# Altium schematic sheet schematic_A.SchDoc(schematic_A)
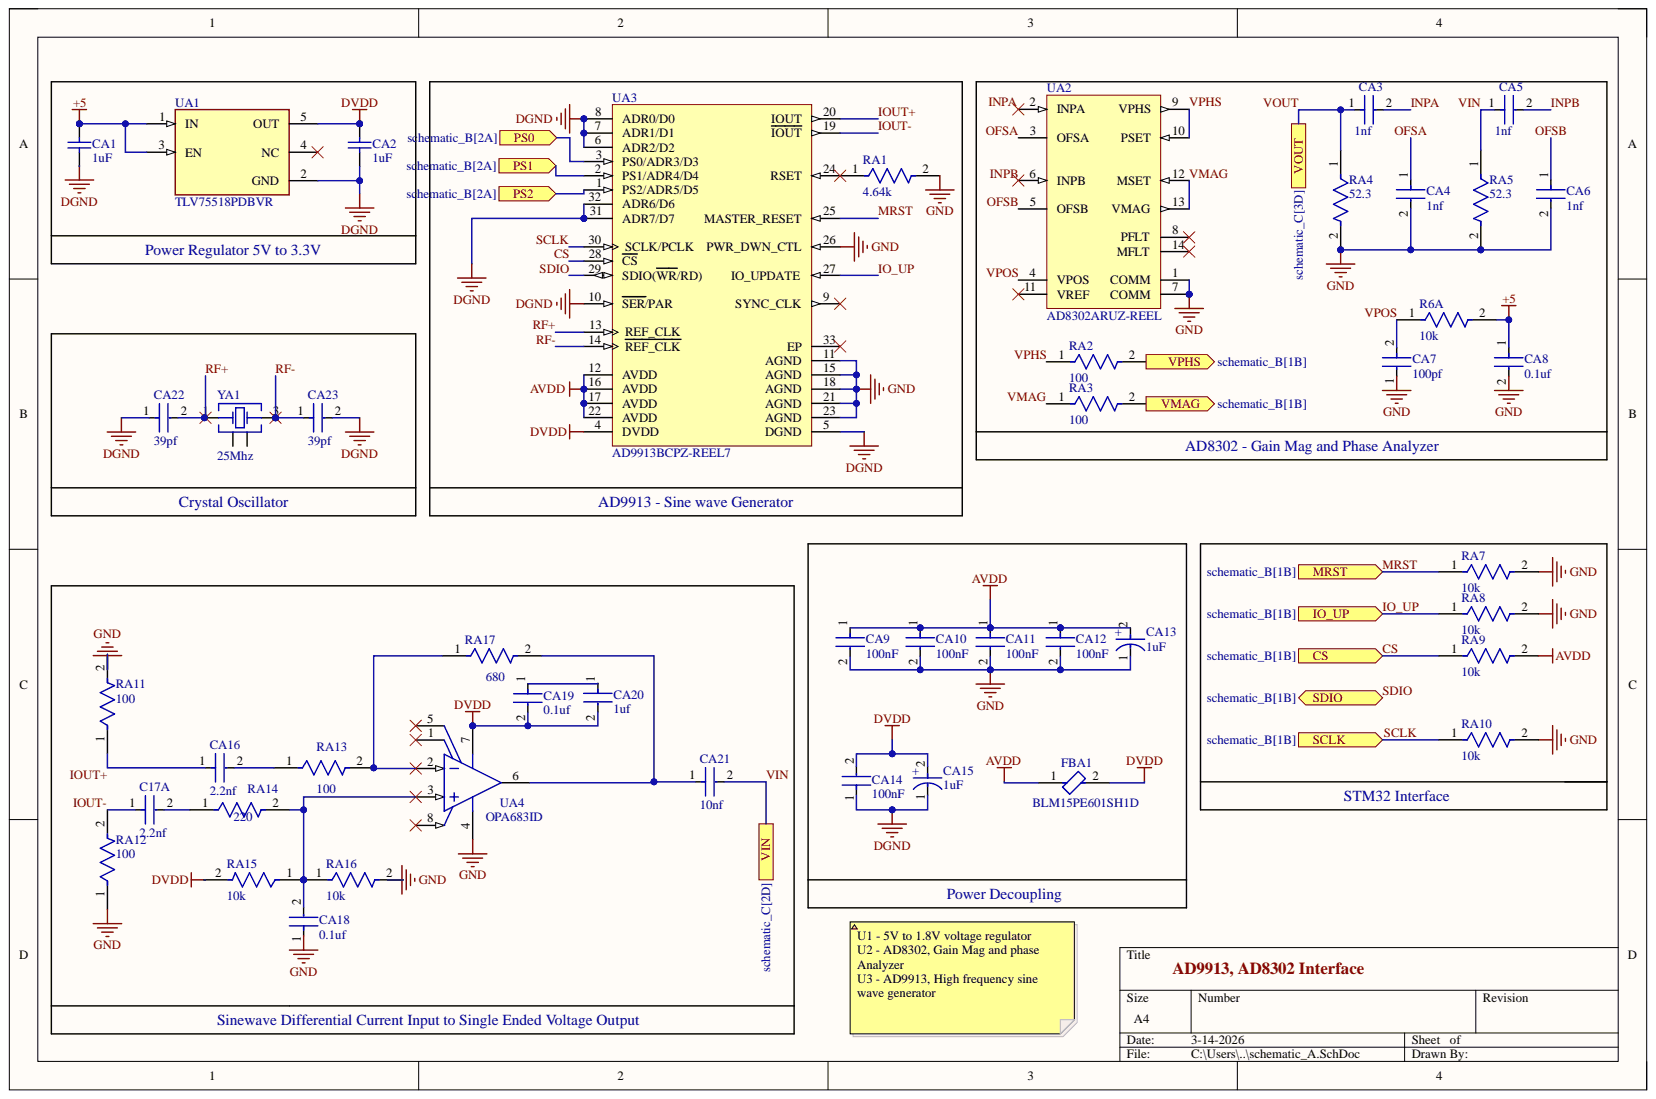
[diagram p0: schematic_A.SchDoc]

## Parts
C17A  2.2nf  [FP-GCM188-0_1-MFG]
CA1  1uF  [CAPC0603X33X15NL03T05]
CA2  1uF  [CAPC0603X33X15NL03T05]
CA3  1nf  [FP-GCM21B-0_2-e0_3_0_75-MFG]
CA4  1nf  [FP-GCM21B-0_2-e0_3_0_75-MFG]
CA5  1nf  [FP-GCM21B-0_2-e0_3_0_75-MFG]
CA6  1nf  [FP-GCM21B-0_2-e0_3_0_75-MFG]
CA7  100pf  [FP-GRT033-0_03-IPC_B]
CA8  0.1uf  [FP-GRM188-0_1-e0_2_0_5-MFG]
CA9  100nF  [FP-0402-L_1_0_0_05-W_0_5-MFG]
CA10  100nF  [FP-0402-L_1_0_0_05-W_0_5-MFG]
CA11  100nF  [FP-0402-L_1_0_0_05-W_0_5-MFG]
CA12  100nF  [FP-0402-L_1_0_0_05-W_0_5-MFG]
CA13  1uF  [FP-293DA-MFG]
CA14  100nF  [FP-0402-L_1_0_0_05-W_0_5-MFG]
CA15  1uF  [FP-293DA-MFG]
CA16  2.2nf  [FP-GCM188-0_1-MFG]
CA18  0.1uf  [FP-GRM188-0_1-e0_2_0_5-MFG]
CA19  0.1uf  [FP-GRM188-0_1-e0_2_0_5-MFG]
CA20  1uf  [FP-CGA3E-0_3-0_1-IPC_B]
CA21  10nf  [FP-GRM022-0_02-IPC_B]
CA22  39pf  [FP-GRM033-0_03-IPC_A]
CA23  39pf  [FP-GRM033-0_03-IPC_A]
FBA1  BLM15PE601SH1D  [FP-BLM15-0_05-MFG]
R6A  10k  [FP-AT0805-MFG]
RA1  4.64k  [FP-RN731J-MFG]
RA2  100  [FP-RNCP0603-MFG]
RA3  100  [FP-RNCP0603-MFG]
RA4  52.3  [FP-RN731E-MFG]
RA5  52.3  [FP-RN731E-MFG]
RA7  10k  [FP-CRGCQ0402-IPC_C]
RA8  10k  [FP-CRGCQ0402-IPC_C]
RA9  10k  [FP-CRGCQ0402-IPC_C]
RA10  10k  [FP-CRGCQ0402-IPC_C]
RA11  100  [FP-RNCP0603-MFG]
RA12  100  [FP-RNCP0603-MFG]
RA13  100  [FP-RNCP0603-MFG]
RA14  220  [FP-MCT0603-IPC_A]
RA15  10k  [FP-AT0805-MFG]
RA16  10k  [FP-AT0805-MFG]
RA17  680  [FP-RT0402-MFG]
UA1  TLV75518PDBVR  [FP-DBV0005A-IPC_A]
UA2  AD8302ARUZ-REEL  [ADI-RU-14_M]
UA3  AD9913BCPZ-REEL7  [ADI-CP-32-2_L]
UA4  OPA683ID  [D0008A_L]
YA1  25Mhz  [WE-XTAL_CFPX-180]

## Ports
CS, IO_UP, MRST, PS0, PS1, PS2, SCLK, SDIO, VIN, VMAG, VOUT, VPHS

## Nets
+5: CA1.1 CA8.1 R6A.2 UA1.1(IN) UA1.3(EN)
AVDD: CA9.1 CA10.1 CA11.1 CA12.1 CA13.2 FBA1.1 RA9.2 UA3.12(AVDD) UA3.16(AVDD) UA3.17(AVDD) UA3.22(AVDD)
DGND: CA1.2 CA2.2 CA14.1 CA15.1 CA22.1 CA23.2 UA1.2(GND) UA3.5(DGND) UA3.6(ADR2/D2) UA3.7(ADR1/D1) UA3.8(ADR0/D0) UA3.10(SER/PAR) UA3.31(ADR7/D7) UA3.32(ADR6/D6)
DVDD: CA2.1 CA14.2 CA15.2 CA19.2 CA20.2 FBA1.2 RA15.2 UA1.5(OUT) UA3.4(DVDD) UA4.7
GND: CA4.2 CA6.2 CA7.1 CA8.2 CA9.2 CA10.2 CA11.2 CA12.2 CA13.1 CA18.1 RA1.2 RA4.2 RA5.2 RA7.2 RA8.2 RA10.2 RA11.2 RA12.1 RA16.2 UA2.1(COMM) UA2.7(COMM) UA3.11(AGND) UA3.15(AGND) UA3.18(AGND) UA3.21(AGND) UA3.23(AGND) UA3.26(PWR_DWN_CTL) UA4.4
CS: RA9.1 UA3.28(CS) ; port CS
INPA: CA3.2 UA2.2(INPA)
INPB: CA5.2 UA2.6(INPB)
IO_UP: RA8.1 UA3.27(IO_UPDATE) ; port IO_UP
IOUT+: CA16.1 RA11.1 UA3.20(IOUT)
IOUT-: C17A.1 RA12.2 UA3.19(IOUT)
MRST: RA7.1 UA3.25(MASTER_RESET) ; port MRST
OFSA: CA4.1 UA2.3(OFSA)
OFSB: CA6.1 UA2.5(OFSB)
PS0: UA3.3(PS0/ADR3/D3) ; port PS0
PS1: UA3.2(PS1/ADR4/D4) ; port PS1
PS2: UA3.1(PS2/ADR5/D5) ; port PS2
RF+: CA22.2 UA3.13(REF_CLK) YA1.1
RF-: CA23.1 UA3.14(REF_CLK) YA1.3
SCLK: RA10.1 UA3.30(SCLK/PCLK) ; port SCLK
SDIO: UA3.29(SDIO(WR/RD)) ; port SDIO
VIN: CA5.1 CA21.2 RA5.1 ; port VIN
VMAG: RA3.1 UA2.12(MSET) UA2.13(VMAG)
VOUT: CA3.1 RA4.1 ; port VOUT
VPHS: RA2.1 UA2.9(VPHS) UA2.10(PSET)
VPOS: CA7.2 R6A.1 UA2.4(VPOS)
NetC17A_2: C17A.2 RA14.1
NetCA16_2: CA16.2 RA13.1
NetCA18_2: CA18.2 RA14.2 RA15.1 RA16.1 UA4.3
NetCA19_1: CA19.1 CA20.1
NetCA21_1: CA21.1 RA17.2 UA4.6
NetRA1_1: RA1.1 UA3.24(RSET)
NetRA2_2: RA2.2 ; port VPHS
NetRA3_2: RA3.2 ; port VMAG
NetRA13_2: RA13.2 RA17.1 UA4.2

Not connected: UA1 4(NC); UA2 8(PFLT) 11(VREF) 14(MFLT); UA3 9(SYNC_CLK) 33(EP); UA4 1 5 8; YA1 2 4

## Library data of the parts
C17A CA16 = CMP-06035-034799-1: Ceramic Capacitor for Automotive 2.2nF  ±5% 63VDC C0G 0603 Paper T/R; Component Kind: Standard; Library Name: Altium Content Vault
CA1 CA2 = CMP-2010-00160-1: Manufacturer: AVX Interconnect / Elco; Part Number: 02016D105KAT2A; Component Kind: Standard; Library Name: Altium Content Vault
CA3-CA6 = CMP-06035-041052-1: Ceramic Capacitor for Automotive 1nF ±5% 630VDC C0G 0805 Embossed T/R; Component Kind: Standard; Library Name: Altium Content Vault
CA7 = CMP-06035-012756-1: Multi-Layer Ceramic Capacitor 100pF 25V C0G ±5% 0201 Paper T/R; Component Kind: Standard; Library Name: Altium Content Vault
CA8 CA18 CA19 = CMP-06035-009454-1: Chip Multilayer Ceramic Capacitors for General Purpose, 0603, 0.10uF, X7R, 15%, 20%, 100V; Capacitance: 100nF; Component Kind: Standard; Dielectric: X7R; Length: 1.6mm; Library Name: Altium Content Vault; Thickness: 800um; Tolerance: 20%; Voltage Rating (DC): 100V; Width: 800um
CA9-CA12 CA14 = CMP-14477-003271-3: Ceramic Capacitor General Use 0.1uF ±10% 25V X5R 0402; NexarPartId: 49252556; Capacitance: 100nF; Case/Package: 0402; Component Kind: Standard; Halogen Free: Halogen Free; Height: 550µm; Length: 990.6µm; Library Name: Altium Content Vault; Material: Ceramic; Max Length: 1.05mm; Max Operating Temperature: 85°C; Max Thickness: 550µm; Max Width: 550µm; Min Length: 950µm; Min Operating Temperature: -55°C; Min Thickness: 450µm; Min Width: 450µm; Mount: Surface Mount; Packaging: Tape & Reel (TR); RoHS: Compliant; Thickness: 558.8µm; Tolerance: 10%; Voltage Rating: 25V; Voltage Rating (DC): 25V; Width: 508µm
CA13 CA15 = CMP-00030-40018767-1: 293D105X9020A2TE3 Cap Tant Solid 1uF 20V A CASE 10% (3.2 X 1.6 X 1.6mm) SMD 3216-18 8.4 Ohm 125 C T/R; Capacitance: 1µF; Dielectric Material: Tantalum; Dissipation Factor: 4%; ESR (Equivalent Series Resistance): 8.4Ω; Leakage Current: 500nA; Polarity: Polar; Q Factor: 4; Ripple Current: 90mA; Tolerance: 20%; Case Code (Imperial): 1206; Case Code (Metric): 3216; Case/Package: 3216-18; Component Kind: Standard; Depth: 1.6mm; Features: General Purpose; Height: 1.6mm; Height - Seated (Max): 1.8034mm; Lead Free: Lead Free; Length: 3.2mm; Library Name: Altium Content Vault; Max Operating Temperature: 125°C; Min Operating Temperature: -55°C; Mount: PCB, Surface Mount; NexarPartId: 40018767; Number of Pins: 2; Packaging: Tape and Reel; Radiation Hardening: No; REACH SVHC: Unknown; RoHS: Compliant; Schedule B: 8532210050, 8532210050; Termination: SMD/SMT; Voltage: 20V; Voltage Rating: 20V; Voltage Rating (DC): 20V; Width: 1.6mm
CA20 = CMP-08246-001878-1: Cap Ceramic 1uF 25V X7R 20% Pad SMD 0603 +125°C Automotive T/R; Capacitance: 1uF; Case Code (Imperial): 0603; Case Code (Metric): 1608; Case/Package: 0603; Component Kind: Standard; Dielectric: X7R; Height: 0.8mm; Length: 0.063inch; Library Name: Altium Content Vault; Max Operating Temperature: 125°C; Min Operating Temperature: -55°C; Mount: Surface Mount; Package Quantity: 4000; Packaging: Tape and Reel; Ratings: AEC-Q200; Thickness: 0.031inch; Tolerance: 20%; Voltage Rating: 25V; Voltage Rating (DC): 25V; Weight: 7.1E-05oz; Width: 0.031inch
CA21 = CMP-06035-063197-1: Chip Multilayer Ceramic Capacitors for General Purpose, 10000pF ±20%, X6S(EIA), ±22.0%, 4Vdc, 01005 (0402M); Component Kind: Standard; Library Name: Altium Content Vault
CA22 CA23 = CMP-2010-01365-2: Chip Multilayer Ceramic Capacitors for General Purpose, 0201, 39pF, C0G, 30ppm/°C, 5%, 25V; Capacitance: 39pF; Case Code (Imperial): 0201; Case Code (Metric): 0603; Case/Package: 0201; Component Kind: Standard; Depth: 0.3mm; Dielectric: C0G; Height: 0.3mm; Lead Free: Lead Free; Length: 0.6mm; Library Name: Altium Content Vault; Max Operating Temperature: 125°C; Min Operating Temperature: -55°C; Mount: Surface Mount; Package Quantity: 15000; Packaging: Tape and Reel; Termination: SMD/SMT; Thickness: 0.013inch; Tolerance: 5%; Voltage Rating: 25V; Voltage Rating (DC): 25V; Width: 0.012inch
FBA1 = CMP-00260-117051428-1: BLM15PE601SH1D Ferrite Bead, 600 Ohm, 25%, 0402 Rohs Compliant: Yes; Resistance: 400mΩ; RMS Current (Irms): 700mA; Test Frequency: 100MHz; Case/Package: 0402; Component Kind: Standard; Core Material: Ferrite; Height: 550µm; Library Name: Altium Content Vault; Lifecycle Status: Production; Max Operating Temperature: 125°C; Min Operating Temperature: -55°C; NexarPartId: 117051428; Series Resistance: 400mΩ; Tolerance: 25%
R6A RA15 RA16 = CMP-03412-049031-1: Thin Film Chip Resistors Automotive Grade 10kΩ 0.5% 50ppm/°C 0805; Max Power Dissipation: 125mW; Resistance: 10kR; Case/Package: 0805; Component Kind: Standard; Features: Moisture Resistant; Height: 0.024inch; Length: 0.079inch; Library Name: Altium Content Vault; Max Operating Temperature: 155°C; Min Operating Temperature: -55°C; Number of Terminations: 2; Packaging: Tape and Reel; Ratings: AEC-Q200; Resistor Type: Thin Film; RoHS Compliant: Yes; Series: AT; Temperature Coefficient: 50ppm/°C; Tolerance: 0.5%; Width: 0.049inch
RA1 = CMP-03211-005291-1: Thin Film Resistors RN 0603 4.64KΩ 0.1% 10ppm/°C; Manufacturer: TE Connectivity; Manufacturer Part Number: RN73C1J4K64BTG; Power Rating: 0.063W; Voltage Rating: 50V; Automotive: No; Category: Res; Component Kind: Standard; ComponentLink2Description: Datasheet; ComponentLink2URL: https://www.te.com/commerce/DocumentDelivery/DDEController?Action=showdoc&DocId=Data+Sheet%7F1773270%7FP%7Fpdf%7FEnglish%7FENG_DS_1773270_P.pdf%7F9-1614352-9; Composition: Thin Film; Device Class L1: Passive Components; Device Class L2: Resistors; Device Class L3: Chip SMD Resistors; Height: 0.55mm; Ipc Land Pattern Name: RESC15580X45; Lead Free: Yes; Library Name: Altium Content Vault; Octopart: https://octopart.com/rn73c1j4k64btg-te+connectivity-44606189; octopart_url: https://octopart.com/rn73c1j4k64btg-te+connectivity-44606189; Package: RN731J; packaging: 250 cut tape length; Resistance: 4.64KΩ; RoHS: TRUE; Series: RN73; Temperature: -55°C to +155°C; Temperature Coefficient: 10ppm/°C; Temperature Range High: +155°C; Temperature Range Low: -55°C; Tolerance: 0.1%; Value: 4.64KΩ
RA2 RA3 RA11-RA13 = CMP-26527-000148-1: 100Ω ±1% 0.125W 0603 High Power Anti-Sulfur Thin Film Chip Resistor; Max Power Dissipation: 125mW; Resistance: 100R; Temperature Coefficient: 100ppm/°C; Case Code (Imperial): 0603; Case Code (Metric): 1608; Case/Package: 0603; Component Kind: Standard; Contact Plating: Tin; Features: Moisture Resistant; Height: 0.02inch; Lead Free: Lead Free; Length: 0.059inch; Library Name: Altium Content Vault; Max Operating Temperature: 155°C; Min Operating Temperature: -55°C; Mount: Surface Mount; Number of Terminations: 2; Packaging: Tape and Reel; Radiation Hardening: No; Resistor Type: Thin Film; RoHS Compliant: Yes; Series: RNCP; Tolerance: 1%; Width: 0.031inch
RA4 RA5 = CMP-03211-009005-1: Res Thin Film 0402 52.3Ω 0.1% 1/16W 10ppm/°C Molded SMD; Manufacturer: TE Connectivity; Manufacturer Part Number: RN73C1E52R3BTDF; Power Rating: 0.063W; Voltage Rating: 25V; Automotive: No; Category: Res; Component Kind: Standard; ComponentLink2Description: Datasheet; ComponentLink2URL: https://www.te.com/commerce/DocumentDelivery/DDEController?Action=showdoc&DocId=Data+Sheet%7F1773270%7FP%7Fpdf%7FEnglish%7FENG_DS_1773270_P.pdf%7F1-1879132-6; Composition: Thin Film; Device Class L1: Passive Components; Device Class L2: Resistors; Device Class L3: Chip SMD Resistors; Height: 0.35mm; Ipc Land Pattern Name: RESC10050X30; Lead Free: Yes; Library Name: Altium Content Vault; Octopart: https://octopart.com/rn73c1e52r3btdf-te+connectivity-22737981; octopart_url: https://octopart.com/rn73c1e52r3btdf-te+connectivity-22737981; Package: RN731E; packaging: 1000 Reel; Resistance: 52.3Ω; RoHS: TRUE; Series: RN73; Temperature: -55°C to +155°C; Temperature Coefficient: 10ppm/°C; Temperature Range High: +155°C; Temperature Range Low: -55°C; Tolerance: 0.1%; Value: 52.3Ω
RA7-RA10 = CMP-03211-008081-1: CRGCQ 0402 10K 5%; Manufacturer: TE Connectivity; Manufacturer Part Number: CRGCQ0402J10K; Power Rating: 0.0625W; Resistance: 10KΩ; Temperature Coefficient: ±100ppm/°C; Tolerance: 0.05; Value: 10KΩ; Voltage Rating: 50V; Ambient Temperature Range High: +70°C; Automotive: Yes; Category: Res; Component Kind: Standard; ComponentLink2Description: Datasheet; ComponentLink2URL: https://www.te.com/commerce/DocumentDelivery/DDEController?Action=showdoc&DocId=Data+Sheet%7F1773204-3%7F1%7Fpdf%7FEnglish%7FENG_DS_1773204-3_1.pdf%7F4-2176347-923; Composition: Thick Film; Device Class L1: Passive Components; Device Class L2: Resistors; Device Class L3: Chip SMD Resistors; Digikey Description: CRGCQ 0402 10K 5%; Height: 0.4mm; Ipc Land Pattern Name: RESC10050X35; Lead Free: Yes; Library Name: Altium Content Vault; Mouser Description: Res Thick Film 0402 10K Ohm 5% 0.063W(1/16W) ±100ppm/C Pad SMD Automotive T/R; Octopart: https://octopart.com/crgcq0402j10k-te+connectivity-91017993; Package: CRGCQ0402; RoHS: TRUE; Series: CRGCQ; Temperature: -55°C to +155°C; Temperature Range High: +155°C; Temperature Range Low: -55°C
RA14 = CMP-02407-001561-1: RES SMD 100 OHM 1% 1/8W 0603; Resistance: 220R; Tolerance: 0.5%; Case/Package: 0603; Component Kind: Standard; Composition: Thin Film; Depth: 0.85mm; Lead Free: Lead Free; Length: 1.55mm; Library Name: Altium Content Vault; Max Operating Temperature: 125°C; Military Standard: Not; Min Operating Temperature: -55°C; Packaging: Tape and Reel
RA17 = CMP-2002-02566-2: RES SMD 680 OHM 1% 1/16W 0402; Manufacturer: Yageo; Manufacturer Part Number: RT0402FRE07680RL; Power Rating: 0.063W; Resistance: 680Ω; Temperature Coefficient: 50 ppm/°C; Tolerance: 0.01; Value: 680Ω; Voltage Rating: 50V; Automotive: No; Category: Res; Component Kind: Standard; ComponentLink2Description: Datasheet; ComponentLink2URL: http://www.yageo.com/documents/recent/PYu-RT_1-to-0.01_RoHS_L_9.pdf; Composition: Thin Film; Device Class L1: Passive Components; Device Class L2: Resistors; Device Class L3: Chip SMD Resistors; Digikey Description: RES SMD 680 OHM 1% 1/16W 0402; Footprint Url: http://www.yageo.com/exep/pages/download/literatures/PYu-R_Mount_10.pdf; Height: 0.35mm; Ipc Land Pattern Name: RESC10050X30; Lead Free: Yes; Library Name: Altium Content Vault; Mouser Description: Res Thin Film 0402 680 Ohm 1% 1/16W ±50ppm/°C Molded SMD SMD Paper T/R; Octopart: https://octopart.com/rt0402fre07680rl-yageo-1234572; Package: RT0402; RoHS: TRUE; Series: RT; Temperature: -55°C to +155°C; Temperature Range High: +155°C; Temperature Range Low: -55°C
UA1 = CMP-04917-000157-1: IC REG LINEAR 1.8V 500MA SOT23-5; Dropout Voltage: 400mV; Max Input Voltage: 5.5V; Max Output Voltage: 3.3V; Number of Regulators: 1; Output Configuration: Positive; Output Type: Fixed; Power Supply Rejection Ratio (PSRR): 52dB; Quiescent Current: 31uA; Case/Package: SOT-23-5; Component Kind: Standard; Library Name: Altium Content Vault; Max Operating Temperature: 125°C; Min Operating Temperature: -40°C; Min Output Voltage: 1.8V; Mount: Surface Mount; Output Current: 500mA; Packaging: Cut Tape
UA2 = CMP-0284-00077-1: LF-2.7 GHz RF/IF Gain and Phase Detector, 60ns Response Time, RU-14, Pb-Free, 2500 Reel; Dynamic Range (dB): 60dB; Product Description: Dual-Channel Gain and Phase Outputs; RF Frequency (MHz): 2700; Supply Current: 19mA; Temp Stability (dB): 1dB; Voltage Supply (V): 2.7 to 5.5; Code_JEDEC: MO-153AB-1; Component Kind: Standard; ComponentLink1Description: Manufacturer URL; ComponentLink1URL: www.analog.com; ComponentLink2Description: Datasheet; ComponentLink2URL: http://www.analog.com/static/imported-files/data_sheets/AD8302.pdf; ComponentLink3Description: Package Specification; ComponentLink3URL: http://www.analog.com/static/imported-files/packages/36057385283879153717235186773RU14.pdf; DatasheetVersion: Rev. A; Library Name: Altium Content Vault; PackageDescription: 14-Lead Thin Shrink Small Outline Package [TSSOP]; PackageReference: RU-14; PackageVersion: Aug-2002; Response Time (ns typ): 60ns
UA3 = CMP-0293-00008-1: Low Power 250 MSPS 10-Bit DAC 1.8 V CMOS Direct Digital Synthesizer, Parallel, Serial, CP-32-2, 1500 Reel, Pb-Free; Compliance Range (V): 800mV; FS Iout (mA nom): 4.6mA; I Supply total (max): 63.5mA; Master fclk: 250MHz; REFCLK Multiplier: Yes; Resolution (Bits): 10bit; Tuning Word Width (bits): 32bit; Code_JEDEC: MO-220-VHHD-2; Component Kind: Standard; ComponentLink1Description: Manufacturer URL; ComponentLink1URL: www.analog.com; ComponentLink2Description: Datasheet; ComponentLink2URL: http://www.analog.com/static/imported-files/data_sheets/AD9913.pdf; ComponentLink3Description: Package Specification; ComponentLink3URL: http://www.analog.com/static/imported-files/packages/414143737956480539664569CP_32_2.pdf; DatasheetVersion: Rev. A; I/O Interface: Parallel, Serial; Library Name: Altium Content Vault; PackageDescription: 32-Lead Lead Frame Chip Scale Package [LFCSP_VQ]; PackageReference: CP-32-2; PackageVersion: Jan-2005
UA4 = CMP-0018-00250-2: Very Low Power Current Feedback Amplifier with Disable, 5 to 12 V, -40 to 85 degC, 8-pin SOIC (D8), Green (RoHS & no Sb/Br); Manufacturer: Texas Instruments; 2nd Harmonic(dBc): 72; 3rd Harmonic(dBc): 88; @ MHz: 5; Acl, min stable gain(V/V): 1; BW @ Acl(MHz): 200; Diff Gain(%): 0.13; Diff Phase(deg): 0.06; Iib(Max)(nA): 5300; Io(Typ)(mA): 110; Iq per channel(Max)(mA): 1.03; Settling Time (0.1%)(Typ)(ns): 12; Slew Rate(Typ)(V/us): 400; Sub Family: High Output Current Amplifier (>=50mA), High Speed Amplifier (>=50MHz); THD (Fc is 1 MHz)(Typ)(dB): -90; Vio(Max)(mV): 3.5; Vn at Flatband(Typ)(nV/rtHz): 4.4; Code_JEDEC: MS-012; Component Kind: Standard; ComponentLink1Description: Manufacturer URL; ComponentLink1URL: http://www.ti.com/; ComponentLink2Description: Datasheet; ComponentLink2URL: http://www.ti.com/general/docs/lit/getliterature.tsp?genericPartNumber=OPA683&fileType=pdf; ComponentLink3Description: Package Specification; ComponentLink3URL: http://www.ti.com/litv/pdf/msoi002j; DatasheetVersion: SBOS221E; Eco-Plan: Green (RoHS & no Sb/Br); Library Name: Altium Content Vault; Mounting Technology: Surface Mount; Number of Channels: 1; PackageDescription: 8-Pin Plastic Small Outline, Body 4.9 x 3.9 mm, Pitch 1.27 mm; PackageReference: D0008A; PackageVersion: 4040047-3/M, 06/2011; PartNumber: OPA683ID; Vs(Max)(V): 12; Vs(Min)(V): 5
YA1 = CMP-03913-116855753-1: 830108174209 WE-XTAL Quartz Crystal; Component Kind: Standard; Frequency: 25MHz; Height: 800µm; Length: 3.2mm; Library Name: Altium Content Vault; Max Operating Temperature: 85°C; Min Operating Temperature: -40°C; NexarPartId: 116855753; Width: 2.5mm
